# Altium schematic sheet Fujin_Main.SchDoc
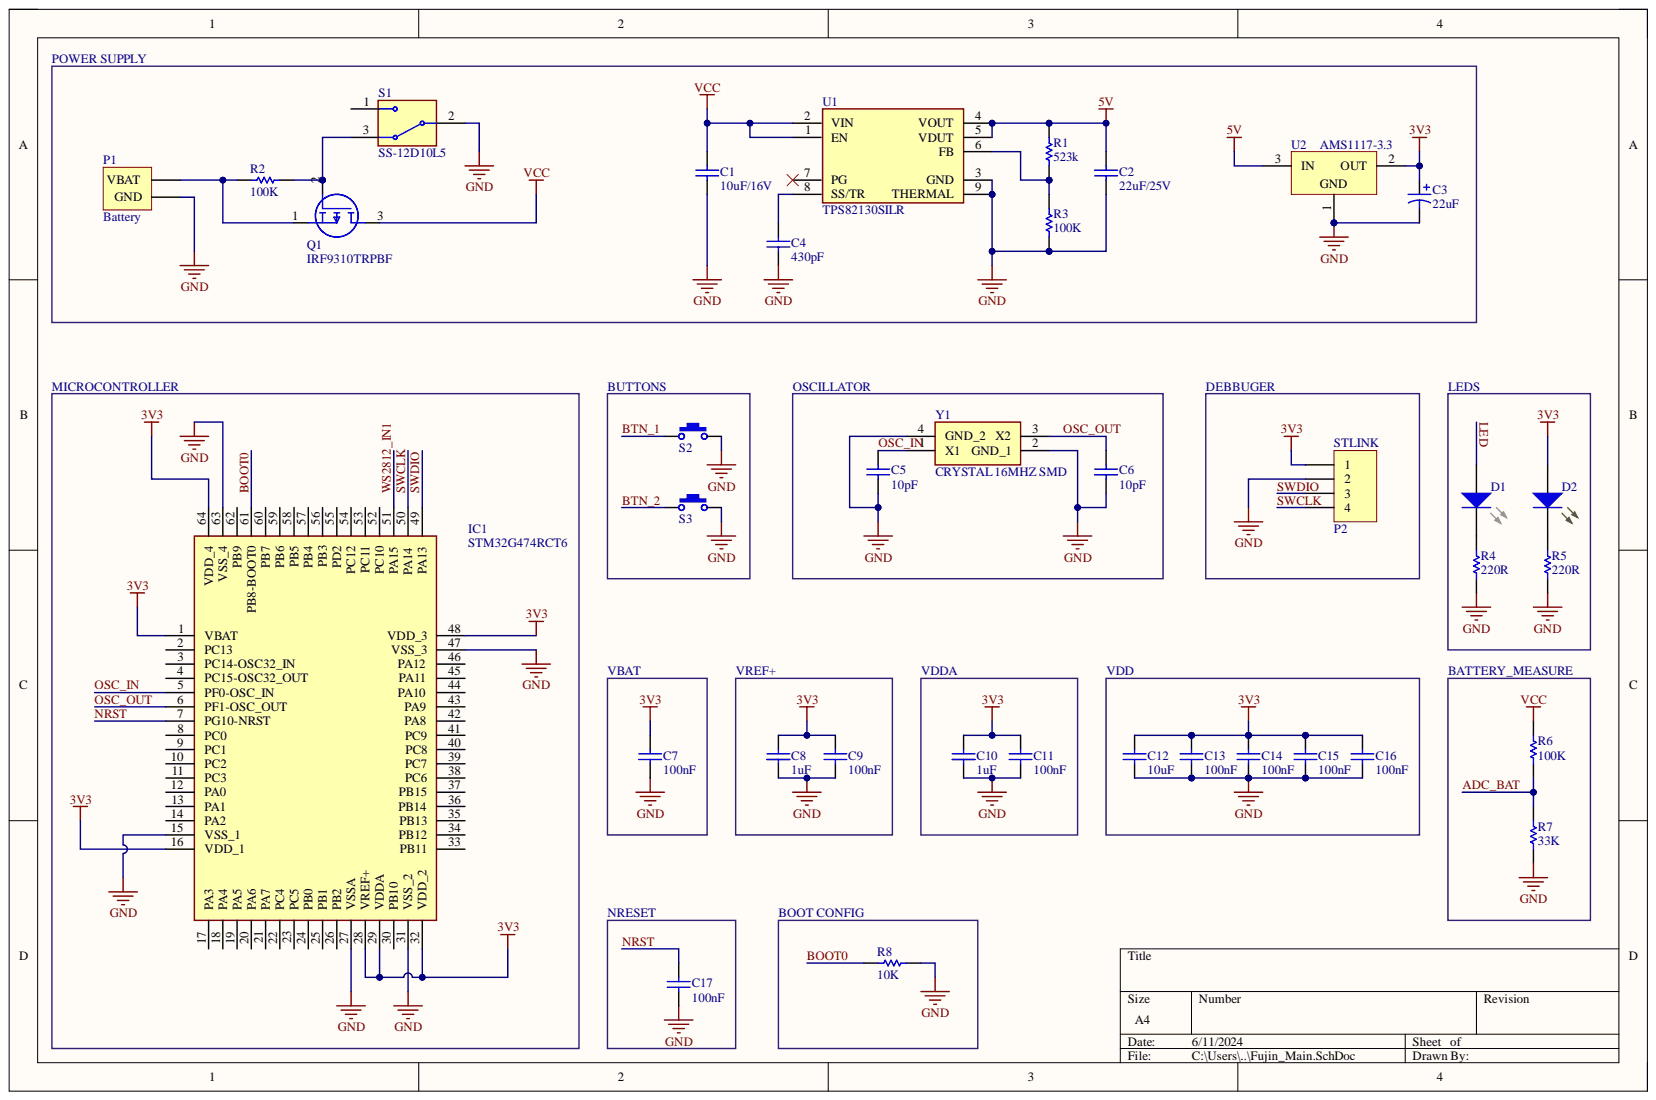
[diagram p0: Fujin_Main.SchDoc]

## Parts
C1  10uF/16V  [CAPACITOR-0805]
C2  22uF/25V  [CAPACITOR-0805]
C3  22uF  [CAPPM6032X280N]
C4  430pF  [Capacitor-0603]
C5  10pF  [Capacitor-0603]
C6  10pF  [Capacitor-0603]
C7  100nF  [Capacitor-0603]
C8  1uF  [Capacitor-0603]
C9  100nF  [Capacitor-0603]
C10  1uF  [Capacitor-0603]
C11  100nF  [Capacitor-0603]
C12  10uF  [CAPACITOR-0805]
C13  100nF  [Capacitor-0603]
C14  100nF  [Capacitor-0603]
C15  100nF  [Capacitor-0603]
C16  100nF  [Capacitor-0603]
C17  100nF  [Capacitor-0603]
D1  LED WHITE  [LED1411 (3528)]  (value not drawn)
D2  LED GREEN  [LED1411 (3528)]  (value not drawn)
IC1  STM32G474RCT6  [QFP50P1200X1200X160-64N]
P1  Battery  [BATTERY CONNECTOR]
P2  Header 1x4  [HDR MALE 1x4]  (value not drawn)
Q1  IRF9310TRPBF  [IRF9310TRPBF]
R1  523k  [RESISTOR 0603]
R2  100K  [RESISTOR 0603]
R3  100K  [RESISTOR 0603]
R4  220R  [RESISTOR 0603]
R5  220R  [RESISTOR 0603]
R6  100K  [RESISTOR 0603]
R7  33K  [RESISTOR 0603]
R8  10K  [RESISTOR 0603]
S1  SS-12D10L5  [1101M2S3CGE2]
S2  SPST-NO  [B3U-1000P]  (value not drawn)
S3  SPST-NO  [B3U-1000P]  (value not drawn)
U1  TPS82130SILR  [SIL0008D]
U2  AMS1117-3.3  [SOT223 - AMS1117]
Y1  CRYSTAL 16MHZ SMD  [ABM10W240000MHZ8K1ZT3]

## Nets
3V3: C3.1 C7.2 C8.2 C9.2 C10.2 C11.2 C12.2 C13.2 C14.2 C15.2 C16.2 D2.1 IC1.1(VBAT) IC1.16(VDD_1) IC1.28(VREF+) IC1.29(VDDA) IC1.32(VDD_2) IC1.48(VDD_3) IC1.64(VDD_4) P2.1 U2.2
5V: C2.2 R1.2 U1.4(VOUT) U1.5(VDUT) U2.3
GND: C1.1 C2.1 C3.2 C4.1 C5.1 C6.1 C7.1 C8.1 C9.1 C10.1 C11.1 C12.1 C13.1 C14.1 C15.1 C16.1 C17.1 IC1.15(VSS_1) IC1.27(VSSA) IC1.31(VSS_2) IC1.47(VSS_3) IC1.63(VSS_4) P1.2 P2.2 R3.1 R4.1 R5.1 R7.1 R8.2 S1.2 S2.2 S3.2 U1.3(GND) U1.9(THERMAL) U2.1 Y1.2(GND_1) Y1.4(GND_2)
VCC: C1.2 Q1.3 R6.2 U1.1(EN) U1.2(VIN)
ADC_BAT: R6.1 R7.2
BOOT0: IC1.61(PB8-BOOT0) R8.1
BTN_1: S2.1
BTN_2: S3.1
LED: D1.1
NRST: C17.2 IC1.7(PG10-NRST)
OSC_IN: C5.2 IC1.5(PF0-OSC_IN) Y1.1(X1)
OSC_OUT: C6.2 IC1.6(PF1-OSC_OUT) Y1.3(X2)
SWCLK: IC1.50(PA14) P2.4
SWDIO: IC1.49(PA13) P2.3
WS2812_IN1: IC1.51(PA15)
NetC4_2: C4.2 U1.8(SS/TR)
NetD1_2: D1.2 R4.2
NetD2_2: D2.2 R5.2
NetP1_1: P1.1 Q1.1 R2.1
NetQ1_2: Q1.2 R2.2 S1.3
NetR1_1: R1.1 R3.2 U1.6(FB)

Not connected: IC1 2(PC13) 3(PC14-OSC32_IN) 4(PC15-OSC32_OUT) 8(PC0) 9(PC1) 10(PC2) 11(PC3) 12(PA0) 13(PA1) 14(PA2) 17(PA3) 18(PA4) 19(PA5) 20(PA6) 21(PA7) 22(PC4) 23(PC5) 24(PB0) 25(PB1) 26(PB2) 30(PB10) 33(PB11) 34(PB12) 35(PB13) 36(PB14) 37(PB15) 38(PC6) 39(PC7) 40(PC8) 41(PC9) 42(PA8) 43(PA9) 44(PA10) 45(PA11) 46(PA12) 52(PC10) 53(PC11) 54(PC12) 55(PD2) 56(PB3) 57(PB4) 58(PB5) 59(PB6) 60(PB7) 62(PB9); S1 1; U1 7(PG)

## Library data of the parts
C1 C12 = CAP CER 10UF 16V X5R 0805: Manufacturer: AVX Corporation; Manufacturer Part Number: 0805YD106KAT2A; Capacitance: 10µF; Tolerance: ±10%; Applications: General Purpose; Category: Capacitors; Component Kind: Standard; ComponentLink1Description: http://datasheets.avx.com/cx5r.pdf; ComponentLink1URL: http://datasheets.avx.com/cx5r.pdf; Description: CAP CER 10UF 16V X5R 0805; Features: -; Height - Seated (Max): -; Lead Spacing: -; Lead Style: -; Mounting Type: Surface Mount, MLCC; Operating Temperature: -55°C ~ 85°C; Package / Case: 0805 (2012 Metric); Packaging: Cut Tape (CT); Part Status: Active; Pricing 1: 1=0.18, 10=0.124, 25=0.0908, 50=0.0742, 100=0.0627, 250=0.05116, 500=0.0429, 1000=0.03795 (USD); Ratings: -; RoHS: RoHS Compliant; Size / Dimension: 0.079" L x 0.049" W (2.01mm x 1.25mm); Stock 1: 198871; Supplier 1: Digi-Key; Supplier Part Number 1: 478-5165-1-ND; Temperature Coefficient: X5R; Thickness (Max): 0.055" (1.40mm); Voltage - Rated: 16V; Library Name: FujinLib.SchLib
C2 = CAP CER 22UF 25V X5R 0805: Manufacturer: AVX Corporation; Manufacturer Part Number: 0805YD106KAT2A; Capacitance: 10µF; Tolerance: ±10%; Voltage - Rated: 16V; Applications: General Purpose; Category: Capacitors; Component Kind: Standard; ComponentLink1Description: http://datasheets.avx.com/cx5r.pdf; ComponentLink1URL: https://www.lcsc.com/product-detail/Multilayer-Ceramic-Capacitors-MLCC-SMD-SMT_Murata-Electronics-GRM21BR61E226ME44L_C86816.html; Description: CAP CER 22UF 25V X5R 0805; Features: -; Height - Seated (Max): -; Lead Spacing: -; Lead Style: -; Mounting Type: Surface Mount, MLCC; Operating Temperature: -55°C ~ 85°C; Package / Case: 0805 (2012 Metric); Packaging: Cut Tape (CT); Part Status: Active; Pricing 1: 1=0.18, 10=0.124, 25=0.0908, 50=0.0742, 100=0.0627, 250=0.05116, 500=0.0429, 1000=0.03795 (USD); Ratings: -; RoHS: RoHS Compliant; Size / Dimension: 0.079" L x 0.049" W (2.01mm x 1.25mm); Stock 1: 198871; Supplier 1: Digi-Key; Supplier Part Number 1: 478-5165-1-ND; Temperature Coefficient: X5R; Thickness (Max): 0.055" (1.40mm); Library Name: FujinLib.SchLib
C3 = CAP 22uF Tantalo: CAP ELE RAD 10uF 16V; Manufacturer Part Number: IMP1295; Capacitance Tolerance: ± 20%; Capacitor Terminals: Radial Leaded; Leakage Current: 6; Lifetime @ Temperature: 2000 hours @ 85; Product Range: MCGPR Series; SVHC: No SVHC (15-Jun-2015); Voltage Rating: 16; Capacitance: 10uF; Capacitance Tolerance ±: ± 20%; Component Kind: Standard; ComponentLink1Description: http://loja.multcomercial.com.br/capacitor-eletrolitico-10uf-16v.html; ComponentLink1URL: http://loja.multcomercial.com.br/capacitor-eletrolitico-10uf-16v.html; Diameter: 5; ESR: -; Height: 11; Lead Spacing: 2; Manufacturer: -; Operating Temperature Max: 85; Operating Temperature Min: -40; Operating Temperature Range: -40°C to +85°C<!--FANDB--><div class="features-and-benefits-container"><div class="features-and-benefits-description"><span class="features-benefits-value">The Multicomp MCGPR series capacitors are general purpose, radial leaded polarized aluminium electrolytic capacitors. MCGPR series parts cover broad range of voltage ratings and capacitance values.</span></div><div class="features-and-benefits-list"><ul class="features-and-benefits-list-list"><li class="features-and-benefits-list-item">Capacitance value ranges from 0.1µF to 22000µF for 6.3VDC to 100VDC</li><li class="features-and-benefits-list-item">Capacitance value ranges from 0.47µF to 820µF for 160VDC to 450VDC</li><li class="features-and-benefits-list-item">Tolerance of ±20%</li><li class="features-and-benefits-list-item">Endurance of 2000h at 85°C</li><li class="features-and-benefits-list-item">Available in -40°C to 85°C and -25°C to 85; Packaging: Each; Pricing 1: 1=0.50 (BRL); RoHS: YES; Stock 1: 33826; Supplier 1: Mult Comercial; Supplier Part Number 1: Capacitor Eletrolítico 10uF/16V; Library Name: FujinLib.SchLib
C4 = CAP 430pF: Capacitor Cer 430pF; Manufacturer Part Number: IMP14925; Capacitance Tolerance: +80%, -20%; Capacitor Terminals: Radial Leaded; Dielectric Characteristic: Y5; Product Range: MCFY Series; SVHC: No SVHC (15-Jun-2015); Voltage Rating: 50; Capacitance Tolerance ±: +80%, -20%; Component Kind: Standard; ComponentLink1Description: http://loja.multcomercial.com.br/capacitor-ceramico-disco-100kpf-50v-104-100k-100nf-0-1uf-cod-1351.html; ComponentLink1URL: http://loja.multcomercial.com.br/capacitor-ceramico-disco-100kpf-50v-104-100k-100nf-0-1uf-cod-1351.html; Lead Spacing: 5; Manufacturer: -; Operating Temperature Max: 85; Operating Temperature Min: -25; Operating Temperature Range: -25°C to +85°C<!--FANDB--><div class="features-and-benefits-container"><div class="features-and-benefits-description"><span class="features-benefits-value">The MCFY series from Multicomp are radial leaded ceramic disc capacitors. The capacitance values ranges from 6800pF to 0.22?F.</span></div><div class="features-and-benefits-list"><ul class="features-and-benefits-list-list"><li class="features-and-benefits-list-item">Large capacitance in small size</li><li class="features-and-benefits-list-item">Rated voltage of 50V</li><li class="features-and-benefits-list-item">80%/-20% tolerance</li><li class="features-and-benefits-list-item">Operating temperature range -25°C to +85; Packaging: Each; Pricing 1: 1=0.10 (BRL); RoHS: YES; Stock 1: 115809; Supplier 1: Mult Comercial; Supplier Part Number 1: Capacitor Cerâmico Disco 100Kpf/50V (104/100K/100nF/0.1uF) cód. 1351; Library Name: FujinLib.SchLib
C5 C6 = CAP 10pF: Capacitor Cer 10pF; Manufacturer Part Number: IMP14925; Capacitance Tolerance: +80%, -20%; Capacitor Terminals: Radial Leaded; Dielectric Characteristic: Y5; Product Range: MCFY Series; SVHC: No SVHC (15-Jun-2015); Voltage Rating: 50; Capacitance Tolerance ±: +80%, -20%; Component Kind: Standard; ComponentLink1Description: http://loja.multcomercial.com.br/capacitor-ceramico-disco-100kpf-50v-104-100k-100nf-0-1uf-cod-1351.html; ComponentLink1URL: http://loja.multcomercial.com.br/capacitor-ceramico-disco-100kpf-50v-104-100k-100nf-0-1uf-cod-1351.html; Lead Spacing: 5; Manufacturer: -; Operating Temperature Max: 85; Operating Temperature Min: -25; Operating Temperature Range: -25°C to +85°C<!--FANDB--><div class="features-and-benefits-container"><div class="features-and-benefits-description"><span class="features-benefits-value">The MCFY series from Multicomp are radial leaded ceramic disc capacitors. The capacitance values ranges from 6800pF to 0.22?F.</span></div><div class="features-and-benefits-list"><ul class="features-and-benefits-list-list"><li class="features-and-benefits-list-item">Large capacitance in small size</li><li class="features-and-benefits-list-item">Rated voltage of 50V</li><li class="features-and-benefits-list-item">80%/-20% tolerance</li><li class="features-and-benefits-list-item">Operating temperature range -25°C to +85; Packaging: Each; Pricing 1: 1=0.10 (BRL); RoHS: YES; Stock 1: 115809; Supplier 1: Mult Comercial; Supplier Part Number 1: Capacitor Cerâmico Disco 100Kpf/50V (104/100K/100nF/0.1uF) cód. 1351; Library Name: FujinLib.SchLib
C7 C9 C11 C13-C17 = CAP 100NF 50V: Capacitor Cer 100nF 50V; Manufacturer Part Number: IMP14925; Capacitance: 0.1uF; Capacitance Tolerance: +80%, -20%; Capacitor Terminals: Radial Leaded; Dielectric Characteristic: Y5; Product Range: MCFY Series; SVHC: No SVHC (15-Jun-2015); Voltage Rating: 50; Capacitance Tolerance ±: +80%, -20%; Component Kind: Standard; ComponentLink1Description: http://loja.multcomercial.com.br/capacitor-ceramico-disco-100kpf-50v-104-100k-100nf-0-1uf-cod-1351.html; ComponentLink1URL: http://loja.multcomercial.com.br/capacitor-ceramico-disco-100kpf-50v-104-100k-100nf-0-1uf-cod-1351.html; Lead Spacing: 5; Manufacturer: -; Operating Temperature Max: 85; Operating Temperature Min: -25; Operating Temperature Range: -25°C to +85°C<!--FANDB--><div class="features-and-benefits-container"><div class="features-and-benefits-description"><span class="features-benefits-value">The MCFY series from Multicomp are radial leaded ceramic disc capacitors. The capacitance values ranges from 6800pF to 0.22?F.</span></div><div class="features-and-benefits-list"><ul class="features-and-benefits-list-list"><li class="features-and-benefits-list-item">Large capacitance in small size</li><li class="features-and-benefits-list-item">Rated voltage of 50V</li><li class="features-and-benefits-list-item">80%/-20% tolerance</li><li class="features-and-benefits-list-item">Operating temperature range -25°C to +85; Packaging: Each; Pricing 1: 1=0.10 (BRL); RoHS: YES; Stock 1: 115809; Supplier 1: Mult Comercial; Supplier Part Number 1: Capacitor Cerâmico Disco 100Kpf/50V (104/100K/100nF/0.1uF) cód. 1351; Library Name: FujinLib.SchLib
C8 C10 = CAP CER 1UF 50V 0603: Multilayer Ceramic Capacitors MLCC - SMD/SMT 1uF 50Volts X5R +/-10% 0603 STD; Manufacturer Part Number: UMK107BJ105KA-T; Brand: Taiyo Yuden; Class: Class 2; Qualification: AEC-Q200; Tolerance: 10 %; Type: Industrial/Automotive and Safety; Voltage Rating DC: 50 VDC; Capacitance: 1 uF; Case Code - in: 0603; Case Code - mm: 1608; Category: Multilayer Ceramic Capacitors MLCC - SMD/SMT; Component Kind: Standard; ComponentLink1Description: http://www.mouser.com/ds/2/396/mlcc_all_e-541559.pdf; ComponentLink1URL: http://www.mouser.com/ds/2/396/mlcc_all_e-541559.pdf; Dielectric: X5R; Height: 0.8 mm; Length: 1.6 mm; Manufacturer: Taiyo Yuden; Maximum Operating Temperature: + 85 C; Minimum Operating Temperature: - 55 C; Minimum Order Quantity: 1; Package / Case: 0603 (1608 metric); Packaging: Reel; Pricing 1: 1=0.512, 10=0.187, 100=0.101, 500=0.072, 1000=0.053, 4000=0.053, 8000=0.038, 12000=0.034, 24000=0.032 (AUD); Product: General Type MLCCs; Reeling: True; RoHS: RoHS Compliant; RoHS - Mouser: Y; Series: M; Stock 1: 123303; Supplier 1: Mouser; Supplier Part Number 1: 1uF; Termination: -; Termination Style: SMD/SMT; Width: 0.8 mm; Library Name: FujinLib.SchLib
D1 = LED WHITE: Standard LEDs - SMD White TOPLED Black Surface; Manufacturer: OSRAM; Manufacturer Part Number: LW TWTG.BB-BYBZ; Luminous Intensity Typ: 2000; Component Kind: Standard; ComponentLink1Description: http://loja.multcomercial.com.br/led-3mm-vermelho-difuso-l-314hd-paralight.html; ComponentLink1URL: http://loja.multcomercial.com.br/led-3mm-vermelho-difuso-l-314hd-paralight.html; ComponentLink2Description: Mouser; ComponentLink2URL: https://br.mouser.com/ProductDetail/OSRAM-Opto-Semiconductors/LW-TWTGBB-BYBZ-2B12C3-Z486?qs=sGAEpiMZZMseGfSY3csMkdgyOOAg6kv20s3Ceu2Hg6%2Fq9qYEXiqoDQ%3D%3D; Library Name: FujinLib.SchLib
D2 = LED GREEN: Standard LEDs - SMD White TOPLED Black Surface; Manufacturer: Wurth Elektronik; Manufacturer Part Number: 150141GS73113; Luminous Intensity Typ: 2500; Component Kind: Standard; ComponentLink3Description: MOUSER2; ComponentLink3URL: https://br.mouser.com/ProductDetail/Wurth-Elektronik/150141GS73113?qs=sGAEpiMZZMseGfSY3csMkdgyOOAg6kv2zYAUfQmngkdzXM%252Bl%252BPVrNQ%3D%3D; Library Name: FujinLib.SchLib
IC1 = STM32G474RCT6: Integrated Circuit; Manufacturer_Name: STMicroelectronics; Component Kind: Standard; Datasheet Link: https://www.mouser.it/datasheet/2/389/dm00431551-1799305.pdf; Height: 1.6mm; Manufacturer_Part_Number: STM32G474RCT6; Mouser Part Number: 511-STM32G474RCT6; Mouser Price/Stock: https://www.mouser.co.uk/ProductDetail/STMicroelectronics/STM32G474RCT6?qs=uwxL4vQweFOU%2FtVbMPY7ow%3D%3D; Library Name: FujinLib.SchLib
P1 = Battery Connector: Component Kind: Standard; Library Name: FujinLib.SchLib
P2 = Header 1x4: Headers & Wire Housings 40Pin 2mm Spacing Single Row Hdrs, 5pc; Manufacturer Part Number: IMP10327; Brand: Cromax; Mounting Angle: Vertical; Number of Positions: 40 Position; Accessory Type: -; Category: Headers & Wire Housings; Component Kind: Standard; ComponentLink1Description: http://loja.multcomercial.com.br/barra-de-pinos-simples-1x40-macho-bmo40-1e.html; ComponentLink1URL: http://loja.multcomercial.com.br/barra-de-pinos-simples-1x40-macho-bmo40-1e.html; Contact Gender: Pin (Male); Contact Plating: Gold; Contact Type: -; Manufacturer: Cromax; Minimum Order Quantity: 1; Mounting Style: Straight Pin; Number of Rows: 1 Row; Pitch: 2 mm; Pricing 1: 1=1.00, 5=0.20 (BRL); Product: Headers; Product Category: Accessories; Reeling: False; RoHS: RoHS Compliant; RoHS - Mouser: Y; Row Spacing: -; Stock 1: 14; Supplier 1: Mult Comercial; Supplier Part Number 1: Barra de Pinos Simples 1x40 Macho BMO40-1E; Termination Style: Through Hole; Type: Breakaway; Library Name: FujinLib.SchLib
Q1 = IRF9310TRPBF: MOSFET (P-Channel); Manufacturer_Name: Infineon; Arrow Part Number: IRF9310TRPBF; Arrow Price/Stock: https://www.arrow.com/en/products/irf9310trpbf/infineon-technologies-ag; Component Kind: Standard; Datasheet Link: http://uk.rs-online.com/web/p/products/8273912; Height: 1.75mm; Manufacturer_Part_Number: IRF9310TRPBF; Mouser Part Number: 942-IRF9310TRPBF; Mouser Price/Stock: https://www.mouser.co.uk/ProductDetail/Infineon-Technologies/IRF9310TRPBF?qs=9%252BKlkBgLFf0NoechZyOTDg%3D%3D; Library Name: FujinLib.SchLib
R1 = RES 523K 1%: Category: Resistors; Component Kind: Standard; ComponentLink1Description: http://www.farnell.com/datasheets/1678796.pdf; ComponentLink1URL: http://www.farnell.com/datasheets/1678796.pdf; ComponentLink2Description: http://industrial.panasonic.com/www-cgi/jvcr13pz.cgi?E+PZ+3+AOA0001+ERJ3GEYJ103V+7+WW; ComponentLink2URL: http://industrial.panasonic.com/www-cgi/jvcr13pz.cgi?E+PZ+3+AOA0001+ERJ3GEYJ103V+7+WW; Resistance (Ohms): 523k; Library Name: FujinLib.SchLib
R2 = RES 100K 5%: Category: Resistors; Component Kind: Standard; ComponentLink1Description: http://www.farnell.com/datasheets/1678796.pdf; ComponentLink1URL: http://www.farnell.com/datasheets/1678796.pdf; ComponentLink2Description: http://industrial.panasonic.com/www-cgi/jvcr13pz.cgi?E+PZ+3+AOA0001+ERJ3GEYJ103V+7+WW; ComponentLink2URL: http://industrial.panasonic.com/www-cgi/jvcr13pz.cgi?E+PZ+3+AOA0001+ERJ3GEYJ103V+7+WW; Resistance (Ohms): 100k; Library Name: FujinLib.SchLib
R3 R6 = RES 100K 1%: Category: Resistors; Component Kind: Standard; ComponentLink1Description: http://www.farnell.com/datasheets/1678796.pdf; ComponentLink1URL: http://www.farnell.com/datasheets/1678796.pdf; ComponentLink2Description: http://industrial.panasonic.com/www-cgi/jvcr13pz.cgi?E+PZ+3+AOA0001+ERJ3GEYJ103V+7+WW; ComponentLink2URL: http://industrial.panasonic.com/www-cgi/jvcr13pz.cgi?E+PZ+3+AOA0001+ERJ3GEYJ103V+7+WW; Resistance (Ohms): 100k; Library Name: FujinLib.SchLib
R4 R5 = RES 220R 5%_1: Manufacturer: Ty-Ohm; Manufacturer Part Number: CR25; Power (Watts): 0.25W, 1/4W; Resistance (Ohms): 470R; Temperature Coefficient: 0/ -500ppm/°C; Tolerance: ±5%; Category: Resistors; Component Kind: Standard; ComponentLink1Description: http://loja.multcomercial.com.br/resistor-de-1-4-de-watt-cr25-5-de-tolerancia.html; ComponentLink1URL: http://loja.multcomercial.com.br/resistor-de-1-4-de-watt-cr25-5-de-tolerancia.html; Composition: Carbon Film; Features: Flame Retardant Coating, Safety; Height: -; Number of Terminations: 2; Operating Temperature: -55°C ~ 155°C; Package / Case: Axial; Packaging: Cut Tape (CT); Pricing 1: 1=0.20 (BRL); RoHS: RoHS Compliant; Size / Dimension: 0.091" Dia x 0.256" L (2.30mm x 6.50mm); Stock 1: 617543; Supplier 1: Mult Comercial; Supplier Device Package: Axial; Supplier Part Number 1: Resistor de Filme de Carbono 1/4 de Watt CR25 5% de tolerância - 0.22R Ω à 10M Ω; Library Name: FujinLib.SchLib
R7 = RES 33K 1%: Component Kind: Standard; ComponentLink1Description: http://www.farnell.com/datasheets/1678796.pdf; ComponentLink1URL: http://www.farnell.com/datasheets/1678796.pdf; ComponentLink2Description: http://industrial.panasonic.com/www-cgi/jvcr13pz.cgi?E+PZ+3+AOA0001+ERJ3GEYJ103V+7+WW; ComponentLink2URL: http://industrial.panasonic.com/www-cgi/jvcr13pz.cgi?E+PZ+3+AOA0001+ERJ3GEYJ103V+7+WW; Library Name: FujinLib.SchLib
R8 = RES 10K 5%: Manufacturer: PANASONIC ELECTRONIC COMPONENTS; Manufacturer Part Number: ERJ-3GEYJ103V; Power (Watts): 0.1W, 1/10W; Temperature Coefficient: ±200ppm/°C; Tolerance: ±5%; Category: Resistors; Component Kind: Standard; ComponentLink1Description: http://www.farnell.com/datasheets/1678796.pdf; ComponentLink1URL: http://www.farnell.com/datasheets/1678796.pdf; ComponentLink2Description: http://industrial.panasonic.com/www-cgi/jvcr13pz.cgi?E+PZ+3+AOA0001+ERJ3GEYJ103V+7+WW; ComponentLink2URL: http://industrial.panasonic.com/www-cgi/jvcr13pz.cgi?E+PZ+3+AOA0001+ERJ3GEYJ103V+7+WW; Composition: Thick Film; Features: Automotive AEC-Q200; Height: 0.022" (0.55mm); Number of Terminations: 2; Operating Temperature: -55°C ~ 155°C; Package / Case: 0603 (1608 Metric); Packaging: Tape & Reel (TR); Resistance (Ohms): 10k; RoHS: YES; Size / Dimension: 0.063" L x 0.031" W (1.60mm x 0.80mm); Supplier Device Package: 0603; Library Name: FujinLib.SchLib
S1 = 1101M2S3CGE2: Switch; Alternate Part Number: XKB Connection SS-12D10L5; Manufacturer_Name: C & K COMPONENTS; Alternate Link: https://www.lcsc.com/product-detail/Slide-Switches_XKB-Connection-SS-12D10L5_C319012.html; Arrow Part Number: 1101M2S3CGE2; Arrow Price/Stock: https://www.arrow.com/en/products/1101m2s3cge2/ck; Component Kind: Standard; Datasheet Link: https://www.ckswitches.com/media/1429/1000.pdf; Height: 11.43mm; LCSC Part: C319012; Manufacturer_Part_Number: 1101M2S3CGE2; Mouser Part Number: 611-1101M2S3CGE2; Mouser Price/Stock: https://www.mouser.co.uk/ProductDetail/CK/1101M2S3CGE2?qs=lwH5WijZ8ehN3XG160Un%2Fg%3D%3D; Library Name: FujinLib.SchLib
S2 S3 = Switch Tactile B3U-1000P: Manufacturer: Omron; Component Kind: Standard; ComponentLink1Description: http://industrial.panasonic.com/cdbs/www-data/pdf/ATK0000/ATK0000CE10.pdf; ComponentLink1URL: https://br.mouser.com/ProductDetail/Omron-Electronics/B3U-1000P?qs=AO7BQMcsEu4ip80xyf2FwA%3D%3D; Supplier 1: Digi-Key; Library Name: FujinLib.SchLib
U1 = TPS82130SILR: Undefined or Miscellaneous; Manufacturer_Name: Texas Instruments; Arrow Part Number: TPS82130SILR; Arrow Price/Stock: https://www.arrow.com/en/products/tps82130silr/texas-instruments?region=europe; Component Kind: Standard; Datasheet Link: http://www.ti.com/lit/gpn/tps82130; Manufacturer_Part_Number: TPS82130SILR; Mouser Part Number: 595-TPS82130SILR; Mouser Price/Stock: https://www.mouser.co.uk/ProductDetail/Texas-Instruments/TPS82130SILR?qs=diC8vqfyxkoyC4Q%252BBNy%2FPA%3D%3D; Library Name: FujinLib.SchLib
Y1 = CRYSTAL 16MHZ SMD: CRYSTAL 16MHZ; Manufacturer_Name: ABRACON; Manufacturer_Part_Number: ABM10W-16.0000MHZ-4-B1U-T3; Arrow Part Number: ABM10W-16.0000MHZ-4-B1U-T3; Arrow Price/Stock: https://www.arrow.com/en/products/abm10w-16.0000mhz-4-b1u-t3/abracon?region=nac; Component Kind: Standard; Datasheet Link: https://www.abracon.com/Resonators/ABM10W.pdf; Height: 0.6mm; Mouser Part Number: 815-10W16-4B1UT; Mouser Price/Stock: https://www.mouser.co.uk/ProductDetail/ABRACON/ABM10W-16.0000MHZ-4-B1U-T3?qs=5aG0NVq1C4x2nD%252BLlu9wCg%3D%3D; Library Name: FujinLib.SchLib
U2 = AMS1117-3.3: Component Kind: Standard; Library Name: FujinLib.SchLib
